# Altium schematic sheet PSU.SchDoc
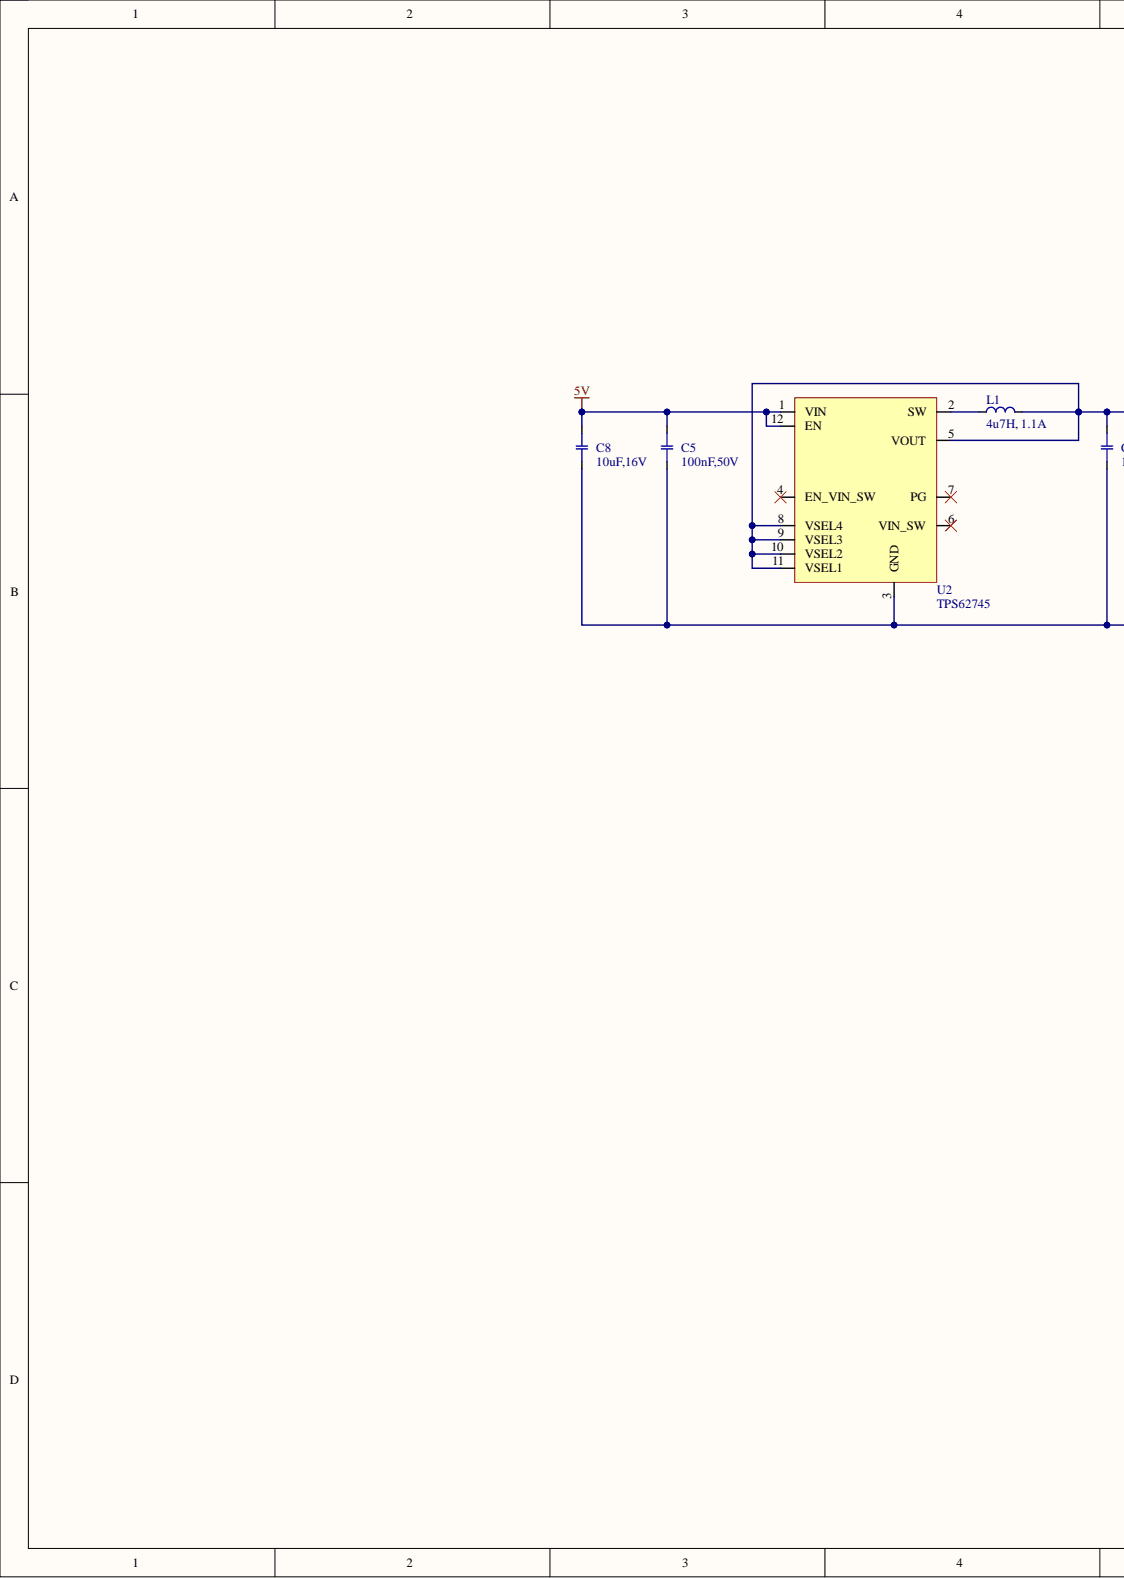
[diagram p0: PSU.SchDoc, left]
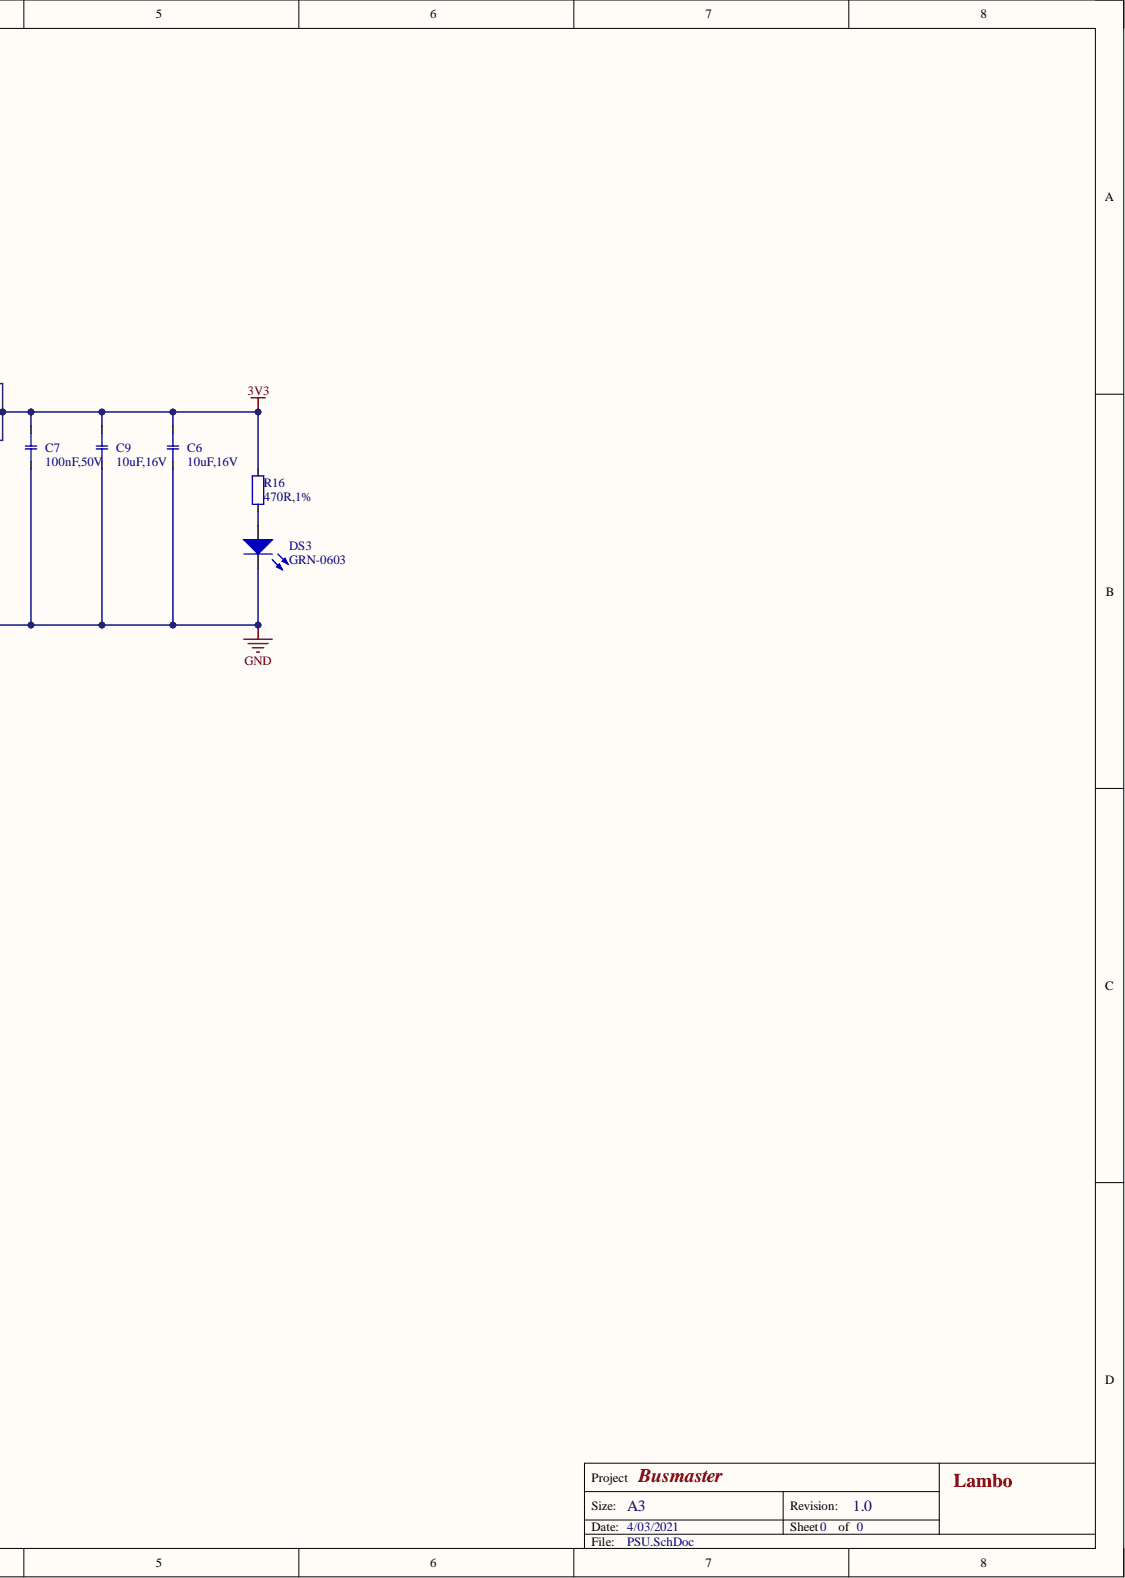
[diagram p1: PSU.SchDoc, right]

## Parts
C5  100nF,50V  [CAPC1608X09N_0603]
C6  10uF,16V  [CAPC2012X12N_0805]
C7  100nF,50V  [CAPC1608X09N_0603]
C8  10uF,16V  [CAPC2012X12N_0805]
C9  10uF,16V  [CAPC2012X12N_0805]
DS3  GRN-0603  [LED_SML-LX0603]
L1  4u7H, 1.1A  Current Rating: 1.1A  [INDC2520X12N_1008]
R16  470R,1%  [RESC1608X06N_0603]
U2  TPS62745  [R-PWSON-N12]

## Nets
3V3: C6.2 C7.2 C9.2 L1.2 R16.2 U2.5(VOUT) U2.8(VSEL4) U2.9(VSEL3) U2.10(VSEL2) U2.11(VSEL1)
5V: C5.2 C8.2 U2.1(VIN) U2.12(EN)
GND: C5.1 C6.1 C7.1 C8.1 C9.1 DS3.1 U2.3(GND)
NetDS3_2: DS3.2 R16.1
NetL1_1: L1.1 U2.2(SW)

Not connected: U2 4(EN_VIN_SW) 6(VIN_SW) 7(PG)

## Library data of the parts
C5 C7 = CCH-104CH-G: CAP CER 0.1UF 50V 10% X7R 0603; Manufacturer: Kemet; Manufacturer Part Number: C0603C104K5RACTU; Database Table Name: c_capacitor; Schematic Symbol: CAP-NP_1; Type: Ceramic; BWA PN: CCH-104CH-G; Capacitance: 100nF; Component Kind: Standard; Dielectric: X7R; Display_Name: 100nF,50V; Library Name: library.Dblib; Supplier 1: Digi-Key; Supplier Part Number 1: 399-5089-1-ND; Tolerance: 10%; Voltage: 50V
C6 C8 C9 = CCI-106CD-G: CAP CER 10µF ±10% 16V X7R 0805; Manufacturer: Samsung Electro-Mechanics America, Inc.; Manufacturer Part Number: CL21B106KOQNNNG; Database Table Name: c_capacitor; Schematic Symbol: CAP-NP_1; Type: Ceramic; BWA PN: CCI-106CD-G; Capacitance: 10uF; Component Kind: Standard; Dielectric: X7R; Display_Name: 10uF,16V; Library Name: library.Dblib; Supplier 1: Digi-Key; Supplier Part Number 1: 1276-6472-1-ND; Tolerance: 10%; Voltage: 16V
DS3 = LED-10087-A: LED GREEN CLEAR 0603 SMD; Manufacturer: Dialight; Manufacturer Part Number: 5988081107F; Axial Intensity: 300mcd; Database Table Name: ds_led; If(max): 20mA; Lens Style/Size: 0603; Schematic Symbol: LED_1; Vf@I: 3.2V; Viewing Angle: 140; Wavelength: 523nm; BWA PN: LED-10087-A; Colour: GREEN; Component Kind: Standard; Display_Name: GRN-0603; Lens type: clear; Library Name: library.Dblib; Supplier 1: Digi-Key; Supplier Part Number 1: 350-2036-1-ND; Vr(max): -
L1 = IND-10165-A: INDUCTOR POWER 4.7UH 1.1A SMD; Manufacturer: Murata Electronics North America; Manufacturer Part Number: DFE252010R-H-4R7M=P2; Database Table Name: l_inductor; DC Resistance: 276mOhm; Schematic Symbol: INDUCTOR_1; Sheilding: Unsheilded; Type: Wirewound; BWA PN: IND-10165-A; Component Kind: Standard; Display_Name: 4u7H, 1.1A; Inductance: 4u7H; Library Name: library.Dblib; Supplier 1: Digi-Key; Supplier Part Number 1: 490-10646-1-ND
R16 = RSH-470R0-D: RES 470 OHM 1/10W 1% 0603 SMD; Manufacturer: Rohm Semiconductor; Manufacturer Part Number: MCR03EZPFX4700; Database Table Name: r_resistor; Power: 0.1W; Schematic Symbol: RESISTOR_1; BWA PN: RSH-470R0-D; Component Kind: Standard; Display_Name: 470R,1%; Library Name: library.Dblib; Resistance: 470R; Supplier 1: Digi-Key; Supplier Part Number 1: RHM470HCT-ND; Tolerance: 1%; Type: Chip
U2 = ICT-10699-A: Step Down Converter; Manufacturer: Texas Instruments; Database Table Name: u_integrated_circuit; Temp Range: -40°C ~ 85°C; BWA PN: ICT-10699-A; Component Kind: Standard; Display_Name: TPS62745; Library Name: library.Dblib; Manufacturer Part Number: TPS62745; Schematic Symbol: TPS62745; Supplier 1: Digi-Key; Supplier 2: Element 14; Supplier Part Number 1: 296-44339-1-ND; Supplier Part Number 2: 2498474
